# Altium schematic sheet CGQ3.SchDoc
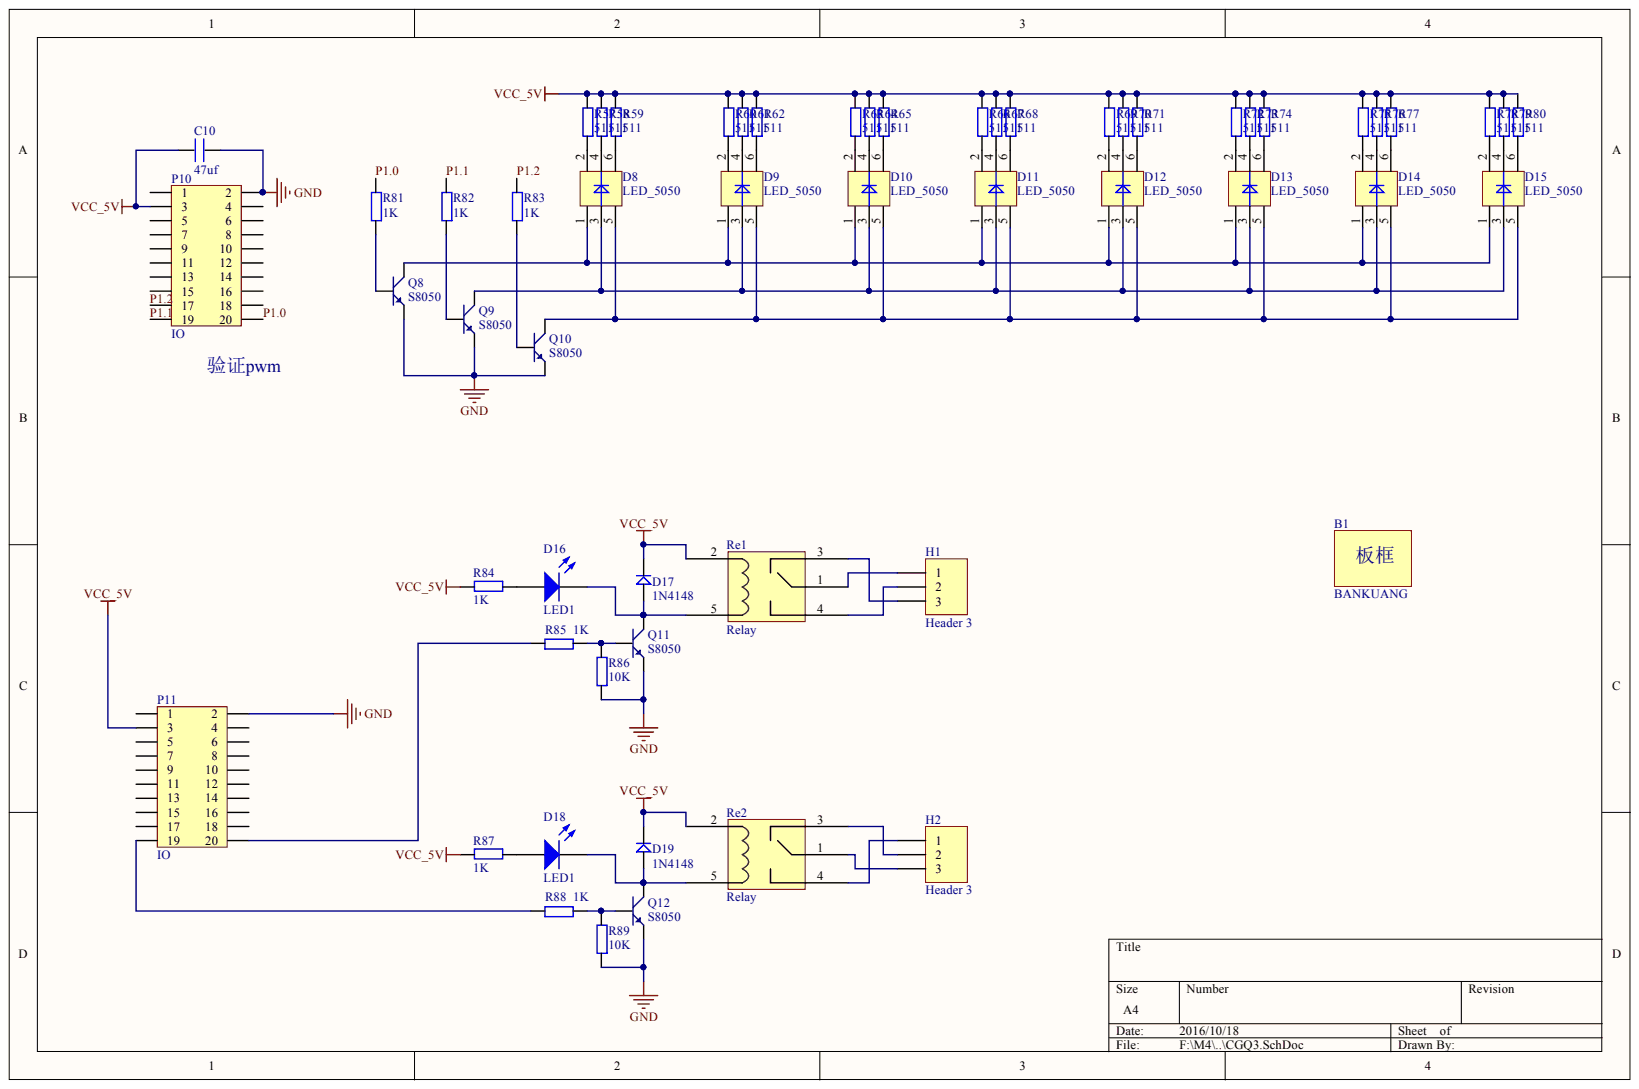
[diagram p0: CGQ3.SchDoc]

## Parts
B1  BANKUANG  [CGQ_BK]
C10  47uf  [1206]
D8  LED_5050  [LED5050]
D9  LED_5050  [LED5050]
D10  LED_5050  [LED5050]
D11  LED_5050  [LED5050]
D12  LED_5050  [LED5050]
D13  LED_5050  [LED5050]
D14  LED_5050  [LED5050]
D15  LED_5050  [LED5050]
D16  LED1  [SMDLED]
D17  1N4148  [DIODE_SOP]
D18  LED1  [SMDLED]
D19  1N4148  [DIODE_SOP]
H1  Header 3  [CON_200]
H2  Header 3  [CON_200]
P10  IO  [SMA_P2X10]
P11  IO  [SMA_P2X10]
Q8  S8050  [SOT-23R]
Q9  S8050  [SOT-23R]
Q10  S8050  [SOT-23R]
Q11  S8050  [SOT-23R]
Q12  S8050  [SOT-23R]
R57  511  [0603P]
R58  511  [0603P]
R59  511  [0603P]
R60  511  [0603P]
R61  511  [0603P]
R62  511  [0603P]
R63  511  [0603P]
R64  511  [0603P]
R65  511  [0603P]
R66  511  [0603P]
R67  511  [0603P]
R68  511  [0603P]
R69  511  [0603P]
R70  511  [0603P]
R71  511  [0603P]
R72  511  [0603P]
R73  511  [0603P]
R74  511  [0603P]
R75  511  [0603P]
R76  511  [0603P]
R77  511  [0603P]
R78  511  [0603P]
R79  511  [0603P]
R80  511  [0603P]
R81  1K  [0603P]
R82  1K  [0603P]
R83  1K  [0603P]
R84  1K  [0603P]
R85  1K  [0603P]
R86  10K  [0603P]
R87  1K  [0603P]
R88  1K  [0603P]
R89  10K  [0603P]
Re1  Relay  [RELAY]
Re2  Relay  [RELAY]

## Nets
GND: C10.2 P10.2 P11.2 Q8.1 Q9.1 Q10.1 Q11.1 Q12.1 R86.1 R89.1
VCC_5V: C10.1 D17.2 D19.2 P10.3 P11.3 R57.2 R58.2 R59.2 R60.2 R61.2 R62.2 R63.2 R64.2 R65.2 R66.2 R67.2 R68.2 R69.2 R70.2 R71.2 R72.2 R73.2 R74.2 R75.2 R76.2 R77.2 R78.2 R79.2 R80.2 R84.2 R87.2 Re1.2 Re2.2
P1.0: P10.20 R81.2
P1.1: P10.19 R82.2
P1.2: P10.17 R83.2
NetD8_1: D8.1 D9.1 D10.1 D11.1 D12.1 D13.1 D14.1 D15.1 Q8.3
NetD8_2: D8.2 R57.1
NetD8_3: D8.3 D9.3 D10.3 D11.3 D12.3 D13.3 D14.3 D15.3 Q9.3
NetD8_4: D8.4 R58.1
NetD8_5: D8.5 D9.5 D10.5 D11.5 D12.5 D13.5 D14.5 D15.5 Q10.3
NetD8_6: D8.6 R59.1
NetD9_2: D9.2 R60.1
NetD9_4: D9.4 R61.1
NetD9_6: D9.6 R62.1
NetD10_2: D10.2 R63.1
NetD10_4: D10.4 R64.1
NetD10_6: D10.6 R65.1
NetD11_2: D11.2 R66.1
NetD11_4: D11.4 R67.1
NetD11_6: D11.6 R68.1
NetD12_2: D12.2 R69.1
NetD12_4: D12.4 R70.1
NetD12_6: D12.6 R71.1
NetD13_2: D13.2 R72.1
NetD13_4: D13.4 R73.1
NetD13_6: D13.6 R74.1
NetD14_2: D14.2 R75.1
NetD14_4: D14.4 R76.1
NetD14_6: D14.6 R77.1
NetD15_2: D15.2 R78.1
NetD15_4: D15.4 R79.1
NetD15_6: D15.6 R80.1
NetD16_1: D16.1 R84.1
NetD16_2: D16.2 D17.1 Q11.3 Re1.5
NetD18_1: D18.1 R87.1
NetD18_2: D18.2 D19.1 Q12.3 Re2.5
NetH1_1: H1.1 Re1.1
NetH1_2: H1.2 Re1.4
NetH1_3: H1.3 Re1.3
NetH2_1: H2.1 Re2.4
NetH2_2: H2.2 Re2.3
NetH2_3: H2.3 Re2.1
NetP11_19: P11.19 R88.1
NetP11_20: P11.20 R85.1
NetQ8_2: Q8.2 R81.1
NetQ9_2: Q9.2 R82.1
NetQ10_2: Q10.2 R83.1
NetQ11_2: Q11.2 R85.2 R86.2
NetQ12_2: Q12.2 R88.2 R89.2

Not connected: P10 1 4 5 6 7 8 9 10 11 12 13 14 15 16 18; P11 1 4 5 6 7 8 9 10 11 12 13 14 15 16 17 18

## Library data of the parts
C10 = C: Component Kind: Standard; Library Name: EXPLORER.SCHLIB
D16 D18 = LED2: Typical RED, GREEN, YELLOW, AMBER GaAs LED; Component Kind: Standard; LatestRevisionDate: 17-Jul-2002; LatestRevisionNote: Re-released for DXP Platform.; Library Name: WARSHIP.SCHLIB; PackageDocument: Feb-2002; PackageReference: 3.2X1.6X1.1; Published: 8-Jun-2000; Simulation: LED2; Publisher: Altium Limited
D17 D19 = D: Component Kind: Standard; Library Name: WARSHIP.SCHLIB
H1 H2 = Header 3: Header, 3-Pin; Component Kind: Standard; LatestRevisionDate: 17-Jul-2002; LatestRevisionNote: Re-released for DXP Platform.; Library Name: Miscellaneous Connectors.IntLib; Signal Integrity: Connector; Publisher: Altium Limited
Q8-Q12 = NPN: 8050/BCW846/BCW847; Component Kind: Standard
  Q8-Q10: Library Name: EXPLORER.SCHLIB
  Q11 Q12: Library Name: WARSHIP.SCHLIB
R57-R89 = R: Component Kind: Standard
  R57-R83: Library Name: EXPLORER.SCHLIB
  R84-R89: Library Name: Explorer STM32F407封装库.IntLib
B1 = BANKUANG: Component Kind: Standard; Library Name: zgb.SchLib
D8-D15 = LED_5050: Component Kind: Standard; Library Name: zgb.SchLib
P10 P11 = IO: Component Kind: Standard; Library Name: zgb.SchLib
Re1 Re2 = Relay: Component Kind: Standard; Library Name: Schlib1.SchLib
